# Altium schematic sheet M3_FMCW.SchDoc(M3_FMCW)
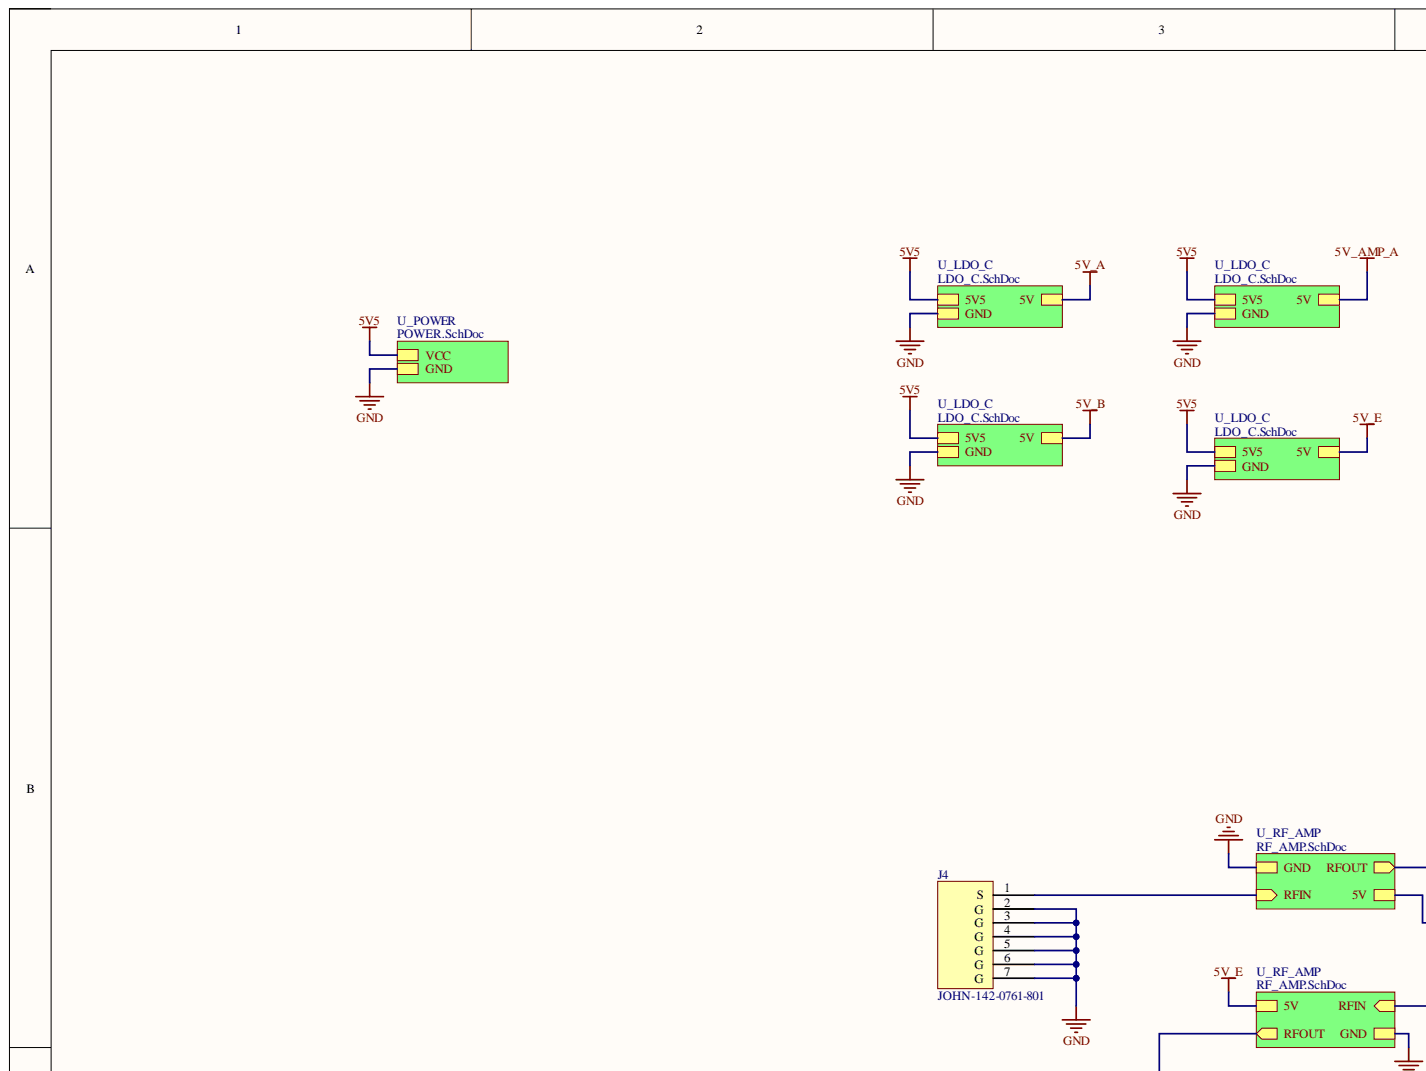
[diagram p0: M3_FMCW.SchDoc, top left]
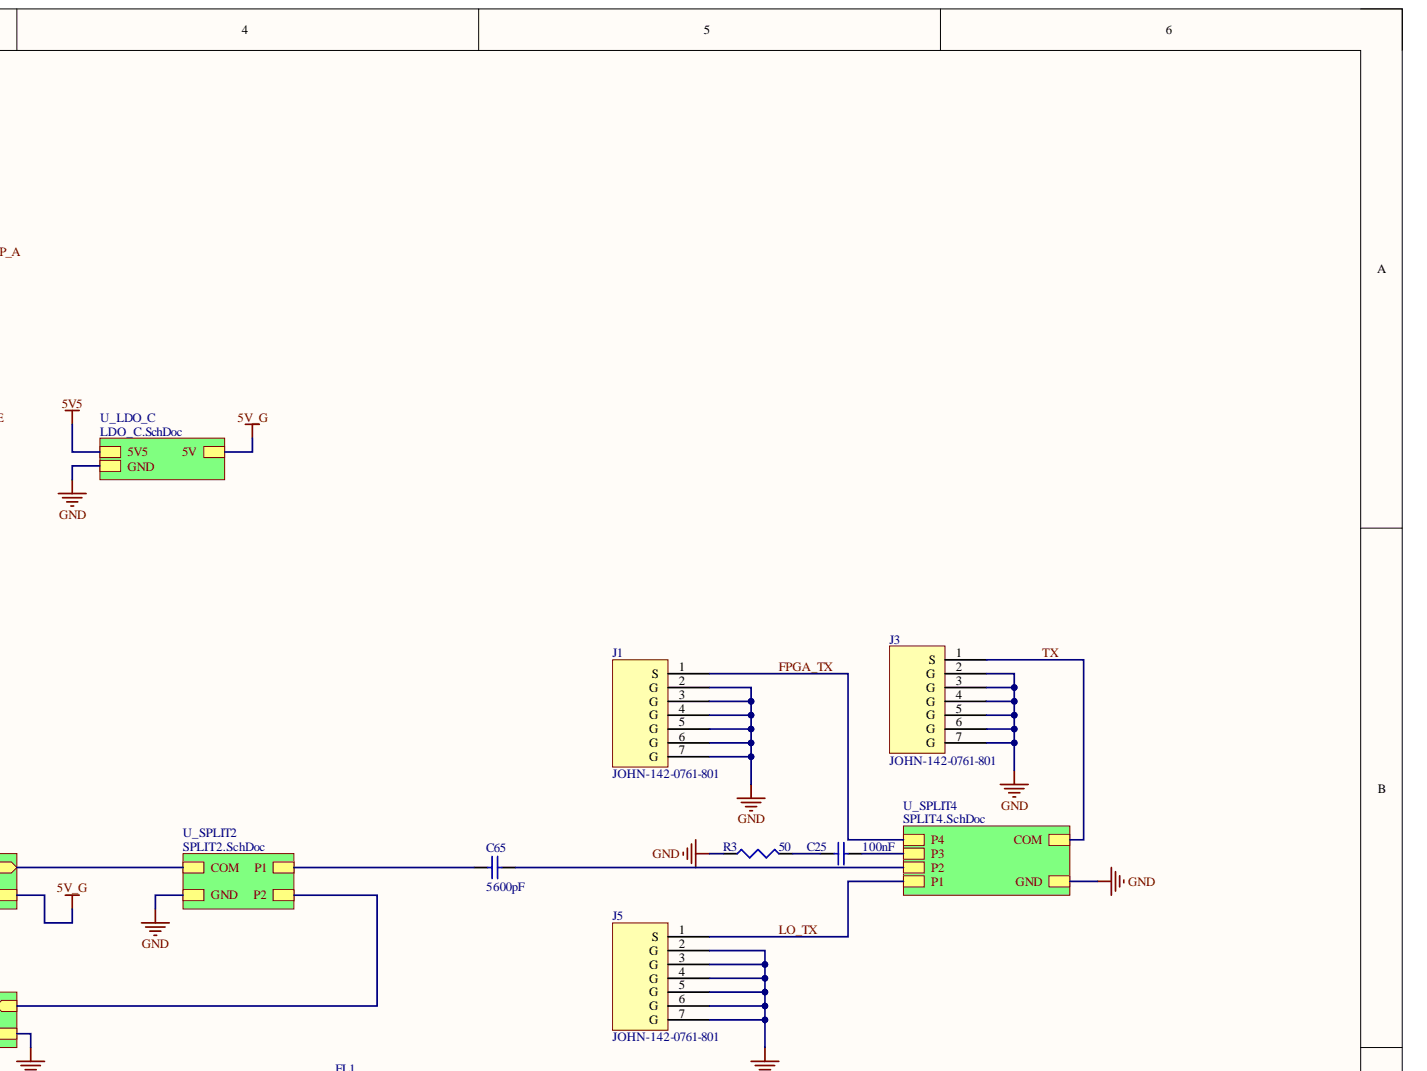
[diagram p1: M3_FMCW.SchDoc, top right]
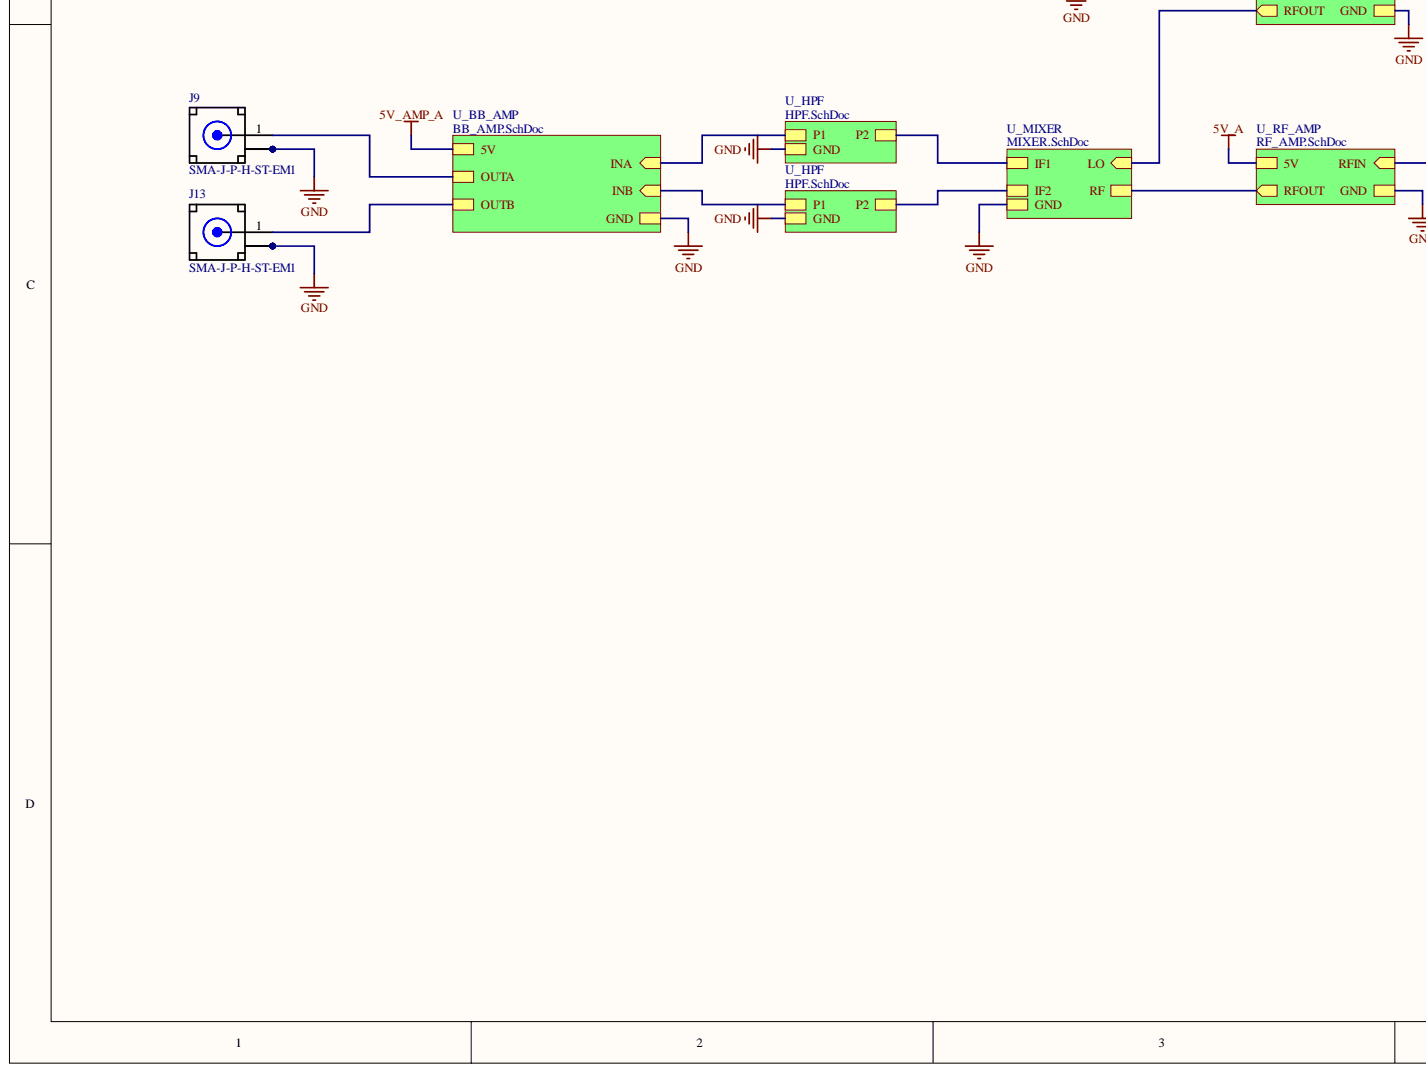
[diagram p2: M3_FMCW.SchDoc, bottom left]
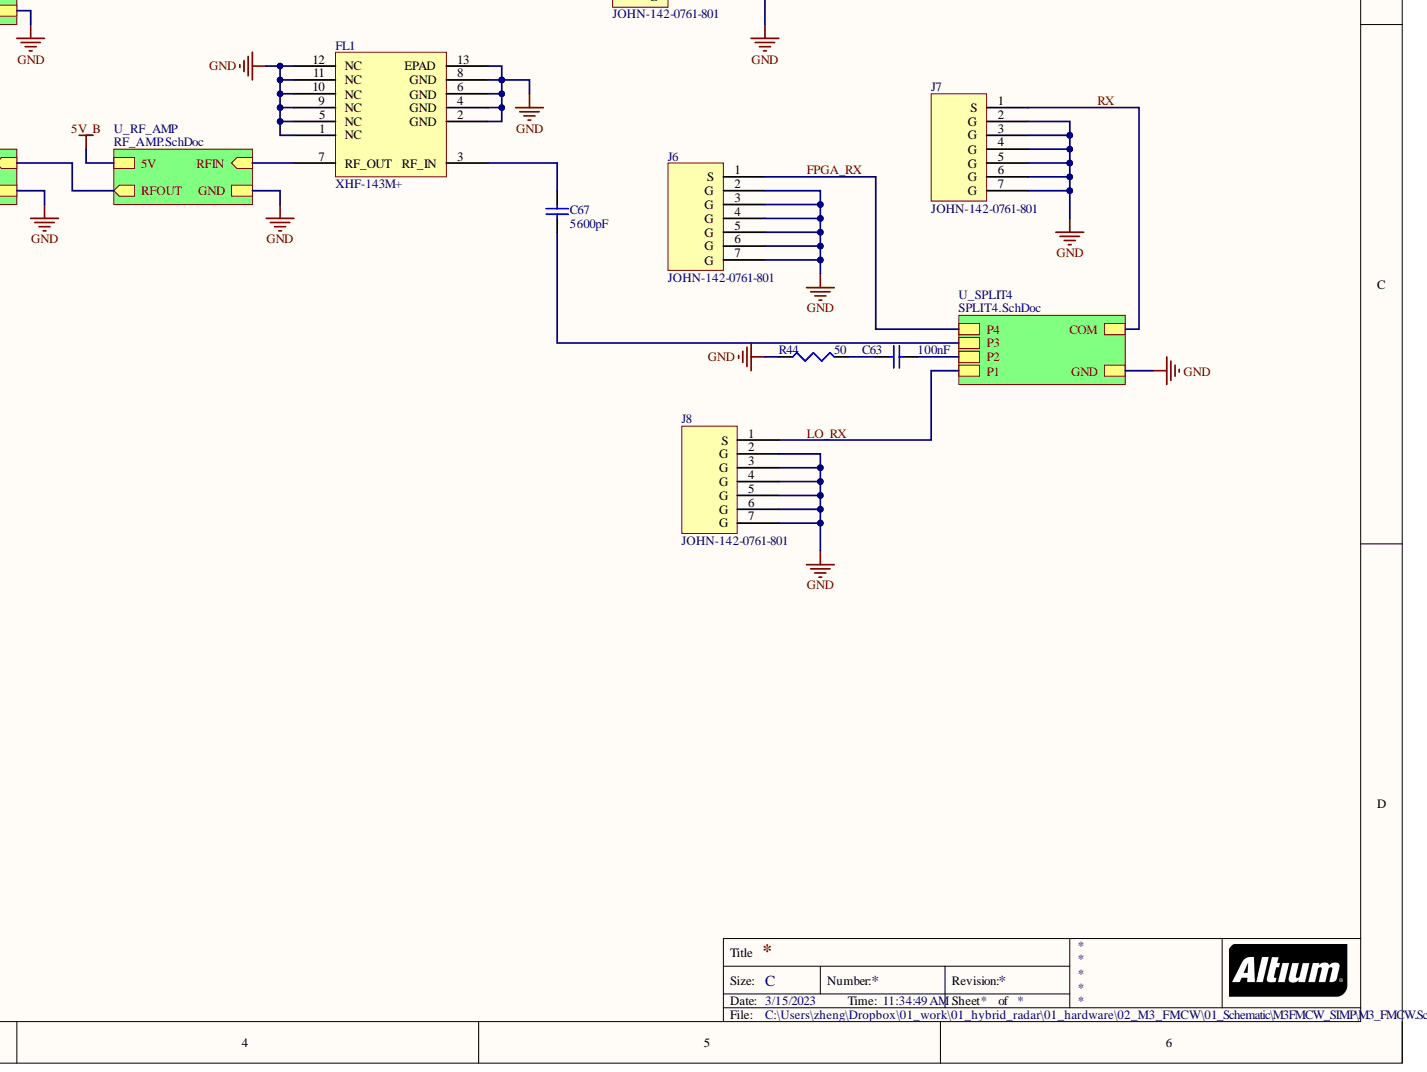
[diagram p3: M3_FMCW.SchDoc, bottom right]

## Parts
C25  100nF  [SMD-0402]
C63  100nF  [SMD-0402]
C65  5600pF  [Johanson-0201]
C67  5600pF  [Johanson-0201]
FL1  XHF-143M+  [PQFN12-XHF-143M]
J1  JOHN-142-0761-801  [JOHN-142-0761-801]
J3  JOHN-142-0761-801  [JOHN-142-0761-801]
J4  JOHN-142-0761-801  [JOHN-142-0761-801]
J5  JOHN-142-0761-801  [JOHN-142-0761-801]
J6  JOHN-142-0761-801  [JOHN-142-0761-801]
J7  JOHN-142-0761-801  [JOHN-142-0761-801]
J8  JOHN-142-0761-801  [JOHN-142-0761-801]
J9  SMA-J-P-H-ST-EM1  [SMA-J-P-H-ST-EM1]
J13  SMA-J-P-H-ST-EM1  [SMA-J-P-H-ST-EM1]
R3  50  [SMD-0402]
R44  50  [SMD-0402]

## Nets
GND: FL1.1(NC) FL1.2(GND) FL1.4(GND) FL1.5(NC) FL1.6(GND) FL1.8(GND) FL1.9(NC) FL1.10(NC) FL1.11(NC) FL1.12(NC) FL1.13(EPAD) J1.2(G) J1.3(G) J1.4(G) J1.5(G) J1.6(G) J1.7(G) J3.2(G) J3.3(G) J3.4(G) J3.5(G) J3.6(G) J3.7(G) J4.2(G) J4.3(G) J4.4(G) J4.5(G) J4.6(G) J4.7(G) J5.2(G) J5.3(G) J5.4(G) J5.5(G) J5.6(G) J5.7(G) J6.2(G) J6.3(G) J6.4(G) J6.5(G) J6.6(G) J6.7(G) J7.2(G) J7.3(G) J7.4(G) J7.5(G) J7.6(G) J7.7(G) J8.2(G) J8.3(G) J8.4(G) J8.5(G) J8.6(G) J8.7(G) J9.2_1 J9.2_2 J9.3_1 J9.3_2 J13.2_1 J13.2_2 J13.3_1 J13.3_2 R3.1 R44.1
FPGA_RX: J6.1(S)
FPGA_TX: J1.1(S)
LO_RX: J8.1(S)
LO_TX: J5.1(S)
RX: J7.1(S)
TX: J3.1(S)
NetC25_1: C25.1 R3.2
NetC25_2: C25.2
NetC63_1: C63.1 R44.2
NetC63_2: C63.2
NetC65_1: C65.1
NetC65_2: C65.2
NetC67_1: C67.1
NetC67_2: C67.2 FL1.3(RF_IN)
NetFL1_7: FL1.7(RF_OUT)
NetJ4_1: J4.1(S)
NetJ9_1: J9.1
NetJ13_1: J13.1
5V5:
5V_A:
5V_AMP_A:
5V_B:
5V_E:
5V_G:
COM:
IF1:
IF2:
INA:
INB:
LO:
P2:
RF:
RFIN:

## Library data of the parts
C25 C63 = CAP_RF_0402: Broadband Ceramic Capacitor X7R 0402 (1005 Metric); Component Kind: Standard; Library Name: LCR.SchLib
C65 C67 = CAP_RF_0201: Broadband Capacitor 0201 Imperial; Component Kind: Standard; Library Name: LCR.SchLib
FL1 = XHF-143M+: Reflectionless High Pass Filter 50Ω 13900 to19000 MHz; Component Kind: Standard; Library Name: FullyDigital.SchLib
J1 J3-J8 = JOHN-142-0761-801: CONN SMA JACK STR 50OHM EDGE MNT; Component Kind: Standard; Library Name: Connectors.SchLib
J9 J13 = SMA-J-P-H-ST-EM1: Connector SMA F 50Ohm Solder ST Edge Mount Gold Over Nickel Tray; MF: Samtec; Availability: Unavailable; Component Kind: Standard; Library Name: Connectors.SchLib; MP: SMA-J-P-H-ST-EM1; Package: None; Price: None; SnapEDA_Link: https://www.snapeda.com/parts/SMA-J-P-H-ST-EM1/Samtec%20Inc./view-part/?ref=snap
R3 R44 = RES_RF_0402: High Frequency Resistor 0402; Component Kind: Standard; Library Name: LCR.SchLib
